# Altium schematic sheet 20_CLOCKS.SchDoc(20_CLOCKS)
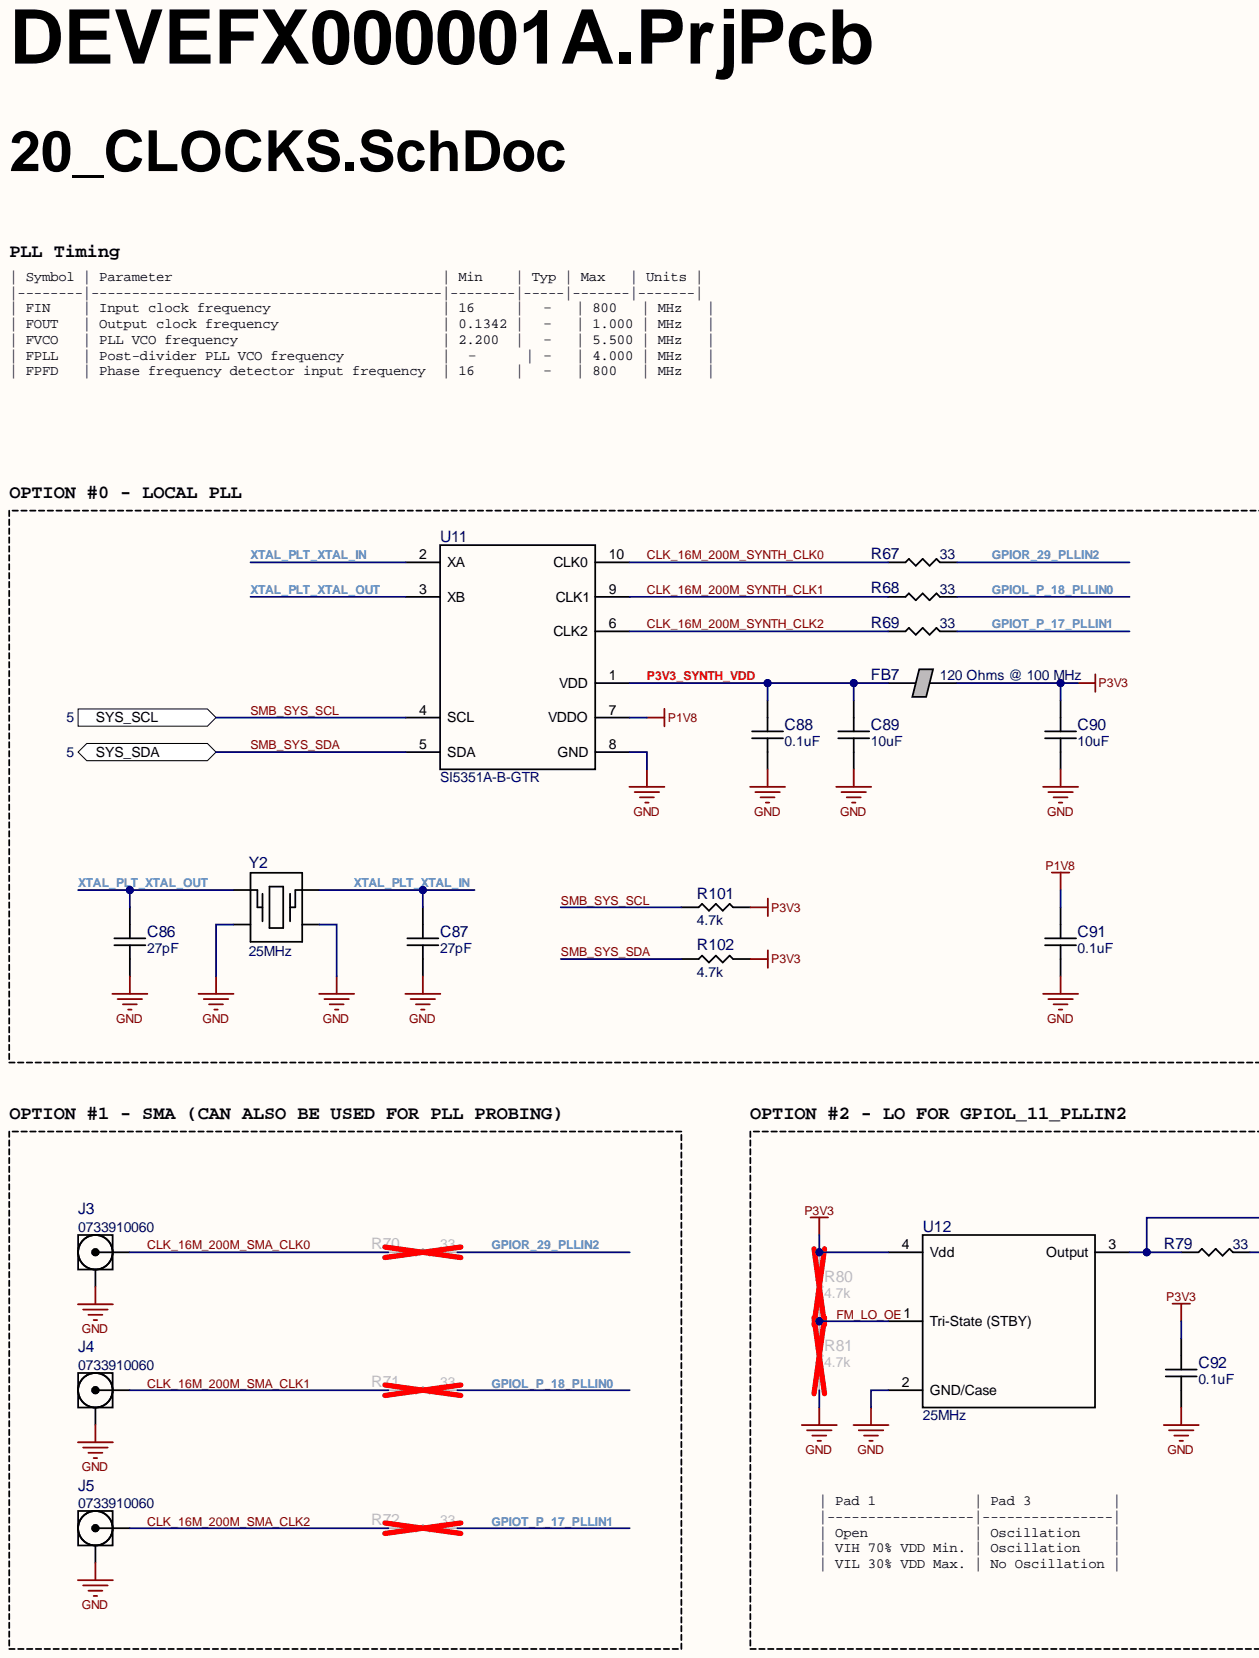
[diagram p0: 20_CLOCKS.SchDoc, left]
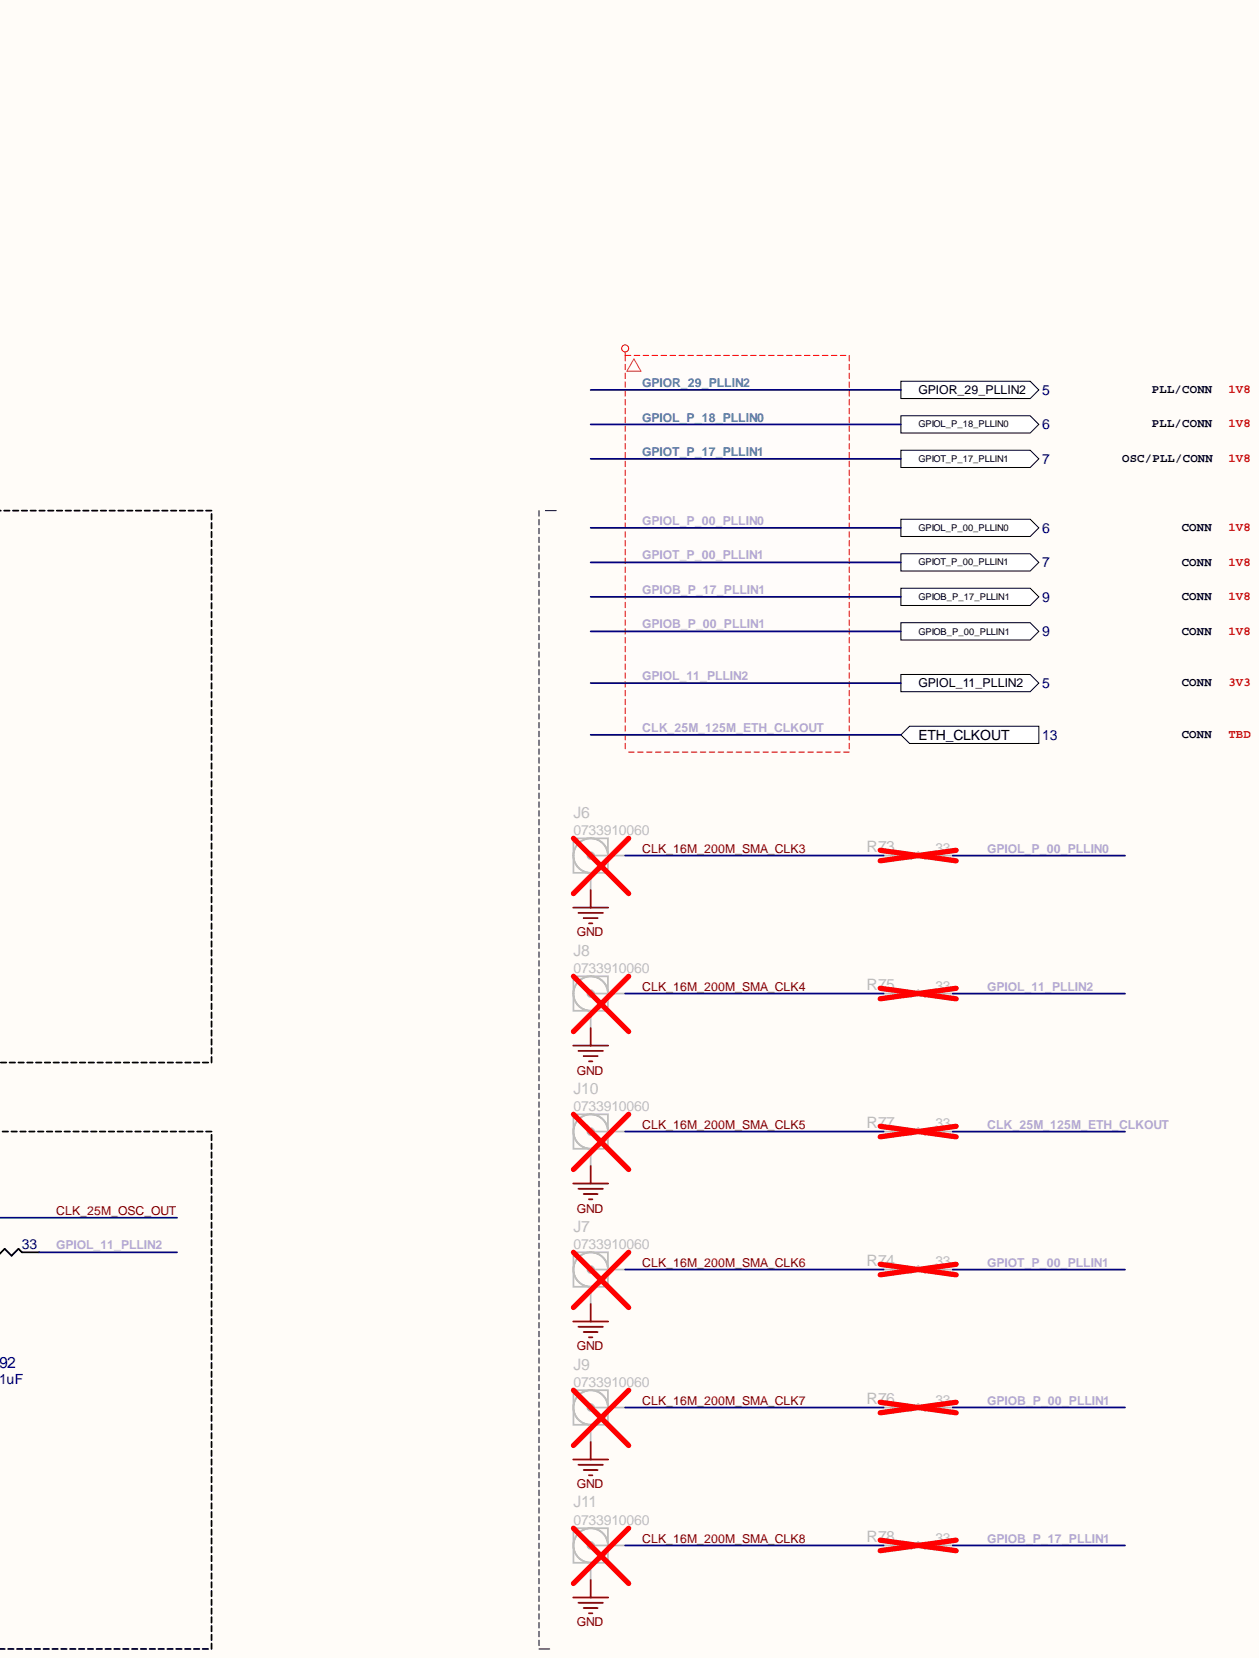
[diagram p1: 20_CLOCKS.SchDoc, right]

## Parts
C86  27pF  [CAPC1005X06N]
C87  27pF  [CAPC1005X06N]
C88  0.1uF  [CAPC1005X06N]
C89  10uF  [CAPC1005X06N]
C90  10uF  [CAPC1005X06N]
C91  0.1uF  [CAPC1005X06N]
C92  0.1uF  [CAPC1005X06N]
FB7  120 Ohms @ 100 MHz  [INDC1005X05N]
J3  0733910060  [MOLEX_0733910060]
J4  0733910060  [MOLEX_0733910060]
J5  0733910060  [MOLEX_0733910060]
J6  0733910060  [MOLEX_0733910060]
J7  0733910060  [MOLEX_0733910060]
J8  0733910060  [MOLEX_0733910060]
J9  0733910060  [MOLEX_0733910060]
J10  0733910060  [MOLEX_0733910060]
J11  0733910060  [MOLEX_0733910060]
R67  33  [RESC1005X03N]
R68  33  [RESC1005X03N]
R69  33  [RESC1005X03N]
R70  33  [RESC1005X03N]
R71  33  [RESC1005X03N]
R72  33  [RESC1005X03N]
R73  33  [RESC1005X03N]
R74  33  [RESC1005X03N]
R75  33  [RESC1005X03N]
R76  33  [RESC1005X03N]
R77  33  [RESC1005X03N]
R78  33  [RESC1005X03N]
R79  33  [RESC1005X03N]
R80  4.7k  [RESC1005X03N]
R81  4.7k  [RESC1005X03N]
R101  4.7k  [RESC1005X03N]
R102  4.7k  [RESC1005X03N]
U11  SI5351A-B-GTR  [TSSOP50P490X110-10N]
U12  25MHz  [XTAL_2520]
Y2  25MHz  [XTAL_3225]

## Ports
ETH_CLKOUT, GPIOB_P_00_PLLIN1, GPIOB_P_17_PLLIN1, GPIOL_11_PLLIN2, GPIOL_P_00_PLLIN0, GPIOL_P_18_PLLIN0, GPIOR_29_PLLIN2, GPIOT_P_00_PLLIN1, GPIOT_P_17_PLLIN1, SYS_SCL, SYS_SDA

## Nets
GND: C86.1 C87.1 C88.1 C89.1 C90.1 C91.1 C92.1 J3.2 J4.2 J5.2 J6.2 J7.2 J8.2 J9.2 J10.2 J11.2 R81.2 U11.8(GND) U12.2(GND/Case) Y2.2 Y2.4
P1V8: C91.2 U11.7(VDDO)
P3V3: C90.2 C92.2 FB7.2 R80.1 R101.2 R102.2 U12.4(Vdd)
CLK_16M_200M_SMA_CLK0: J3.1 R70.1
CLK_16M_200M_SMA_CLK1: J4.1 R71.1
CLK_16M_200M_SMA_CLK2: J5.1 R72.1
CLK_16M_200M_SMA_CLK3: J6.1 R73.1
CLK_16M_200M_SMA_CLK4: J8.1 R75.1
CLK_16M_200M_SMA_CLK5: J10.1 R77.1
CLK_16M_200M_SMA_CLK6: J7.1 R74.1
CLK_16M_200M_SMA_CLK7: J9.1 R76.1
CLK_16M_200M_SMA_CLK8: J11.1 R78.1
CLK_16M_200M_SYNTH_CLK0: R67.1 U11.10(CLK0)
CLK_16M_200M_SYNTH_CLK1: R68.1 U11.9(CLK1)
CLK_16M_200M_SYNTH_CLK2: R69.1 U11.6(CLK2)
CLK_25M_125M_ETH_CLKOUT: R77.2 ; port ETH_CLKOUT
CLK_25M_OSC_OUT: R79.1 U12.3(Output)
FM_LO_OE: R80.2 R81.1 U12.1(Tri-State_(STBY))
GPIOB_P_00_PLLIN1: R76.2 ; port GPIOB_P_00_PLLIN1
GPIOB_P_17_PLLIN1: R78.2 ; port GPIOB_P_17_PLLIN1
GPIOL_11_PLLIN2: R75.2 R79.2 ; port GPIOL_11_PLLIN2
GPIOL_P_00_PLLIN0: R73.2 ; port GPIOL_P_00_PLLIN0
GPIOL_P_18_PLLIN0: R68.2 R71.2 ; port GPIOL_P_18_PLLIN0
GPIOR_29_PLLIN2: R67.2 R70.2 ; port GPIOR_29_PLLIN2
GPIOT_P_00_PLLIN1: R74.2 ; port GPIOT_P_00_PLLIN1
GPIOT_P_17_PLLIN1: R69.2 R72.2 ; port GPIOT_P_17_PLLIN1
P3V3_SYNTH_VDD: C88.2 C89.2 FB7.1 U11.1(VDD)
SMB_SYS_SCL: R101.1 U11.4(SCL) ; port SYS_SCL
SMB_SYS_SDA: R102.1 U11.5(SDA) ; port SYS_SDA
XTAL_PLT_XTAL_IN: C87.2 U11.2(XA) Y2.3
XTAL_PLT_XTAL_OUT: C86.2 U11.3(XB) Y2.1

## Library data of the parts
C86 C87 = CC0402JRNPO9BN270: CAP CER 27PF 50V C0G/NPO 0402; Manufacturer 1: Yageo; Database Table Name: C$; TempC: C0G/NP0; Tol: 5%; Component Kind: Standard; Library Name: Database.DbLib; Manufacturer Part Number 1: CC0402JRNPO9BN270; Part Number: CC0402JRNPO9BN270; Supplier 1: Digi-Key; Supplier Part Number 1: 311-1019-1-ND; Value: 27pF; Voltage: 50V
C88 C91 C92 = GRM155R71E104KE14J: CAP CER 0.1UF 25V X7R 0402; Manufacturer 1: Murata Electronics; Database Table Name: C$; Tol: 10%; Component Kind: Standard; Library Name: Database.DbLib; Manufacturer Part Number 1: GRM155R71E104KE14J; Part Number: GRM155R71E104KE14J; Supplier 1: Digi-Key; Supplier Part Number 1: 490-14603-1-ND; TempC: X7R; Value: 0.1uF; Voltage: 25V
C89 C90 = GRM155R60J106ME47D: CAP CER 10UF 6.3V X5R 0402; Manufacturer 1: Murata Electronics; Database Table Name: C$; Tol: 20%; Component Kind: Standard; Library Name: Database.DbLib; Manufacturer Part Number 1: GRM155R60J106ME47D; Part Number: GRM155R60J106ME47D; Supplier 1: Digi-Key; Supplier Part Number 1: 490-13239-1-ND; TempC: X5R; Value: 10uF; Voltage: 6.3V
FB7 = BLM15PD121SN1D: FERRITE BEAD 120 OHM 0402 1LN; Manufacturer 1: Murata Electronics; Database Table Name: L$; DCR: 90mOhm; Ir: 1.3A; Component Kind: Standard; Library Name: Database.DbLib; Manufacturer Part Number 1: BLM15PD121SN1D; Part Number: BLM15PD121SN1D; Supplier 1: Digi-Key; Supplier Part Number 1: 490-5203-1-ND; Value: 120 Ohms @ 100 MHz
J3-J11 = 0733910060: CONN SMA RCPT STR 50 OHM PCB; Manufacturer 1: Molex; Database Table Name: Connectors$; Component Kind: Standard; Library Name: Database.DbLib; Manufacturer Part Number 1: 0733910060; Part Number: 0733910060; Supplier 1: Digi-Key; Supplier Part Number 1: WM5543-ND
R67-R79 = RC0402FR-0733RL: RES SMD 33 OHM 1% 1/16W 0402; Manufacturer 1: Yageo; Database Table Name: R$; Component Kind: Standard; Library Name: Database.DbLib; Manufacturer Part Number 1: RC0402FR-0733RL; Part Number: RC0402FR-0733RL; Supplier 1: Digi-Key; Supplier Part Number 1: 311-33.0LRCT-ND; Tol: 1%; Value: 33
R80 R81 R101 R102 = RC0402FR-074K7L: RES SMD 4.7K OHM 1% 1/16W 0402; Manufacturer 1: Yageo; Database Table Name: R$; Component Kind: Standard; Library Name: Database.DbLib; Manufacturer Part Number 1: RC0402FR-074K7L; Part Number: RC0402FR-074K7L; Supplier 1: Digi-Key; Supplier Part Number 1: 311-4.7KLRCT-ND; Tol: 1%; Value: 4.7k
U11 = SI5351A-B-GTR: IC CLK GENERATOR 200MHZ 10MSOP; Manufacturer 1: Skyworks Solutions Inc.; Database Table Name: ICs$; Component Kind: Standard; Library Name: Database.DbLib; Manufacturer Part Number 1: SI5351A-B-GTR; Part Number: SI5351A-B-GTR; Supplier 1: Digi-Key; Supplier Part Number 1: 336-3908-1-ND
U12 = ECS-2033-250-BN: XTAL OSC XO 25.0000MHZ CMOS SMD; Manufacturer 1: ECS Inc.; Database Table Name: Crystals$; Component Kind: Standard; Library Name: Database.DbLib; Manufacturer Part Number 1: ECS-2033-250-BN; Part Number: ECS-2033-250-BN; Supplier 1: Digi-Key; Supplier Part Number 1: XC1433CT-ND; Value: 25MHz
Y2 = TSX-3225 25.0000MF10P-C0: CRYSTAL 25.0000MHZ 18PF SMD; Manufacturer 1: EPSON; Database Table Name: Crystals$; Component Kind: Standard; Library Name: Database.DbLib; Manufacturer Part Number 1: TSX-3225 25.0000MF10P-C0; Part Number: TSX-3225 25.0000MF10P-C0; Supplier 1: Digi-Key; Supplier Part Number 1: SER4211TR-ND; Value: 25MHz
